# Altium schematic sheet Setback.SchDoc
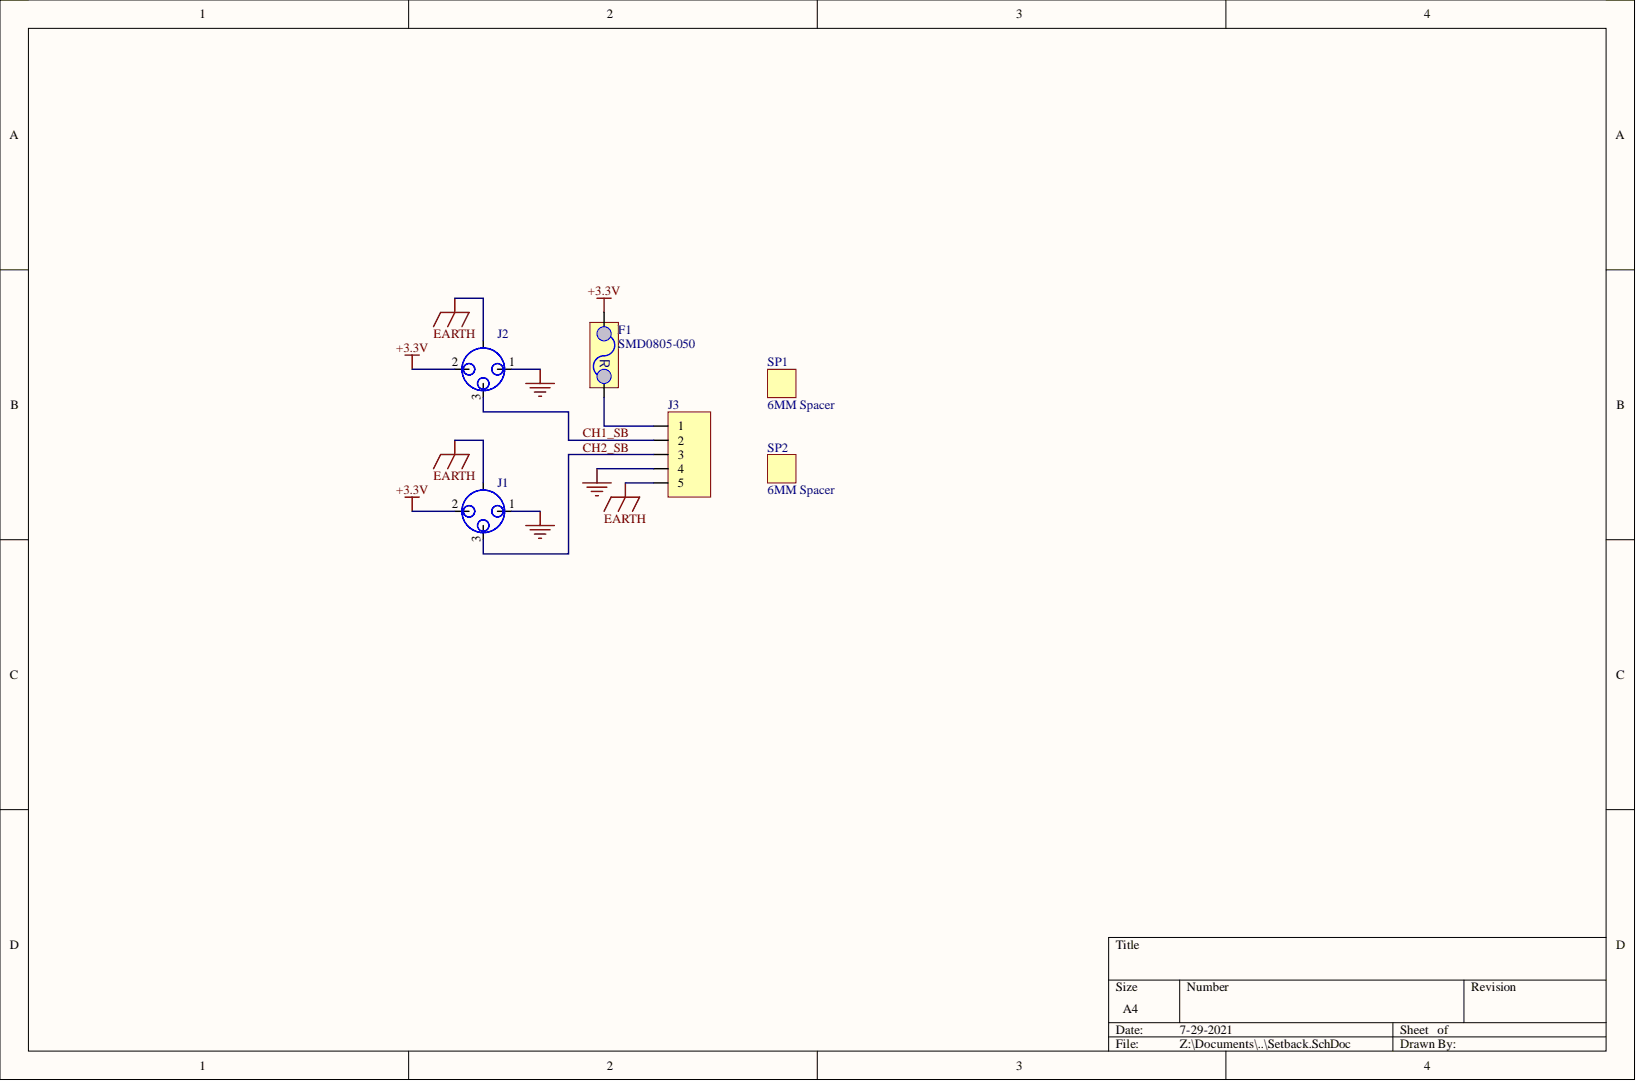
[diagram p0: Setback.SchDoc]

## Parts
F1  SMD0805-050  [SMD0805-050]
J1  DIN-MINI-3-Pin  [MIN-DIN-3-pin-socket]  (value not drawn)
J2  DIN-MINI-3-Pin  [MIN-DIN-3-pin-socket]  (value not drawn)
J3  JST XH Connector, 5-Pin  [jst-b5b-xh-ax]  (value not drawn)
SP1  6MM Spacer  [Spacer-2mm dia x 6mm]
SP2  6MM Spacer  [Spacer-2mm dia x 6mm]

## Nets
+3.3V: F1.1 J1.2 J2.2
EARTH: J1.SH J2.SH J3.5
GND: J1.1 J2.1 J3.4
CH1_SB: J2.3 J3.2
CH2_SB: J1.3 J3.3
NetF1_2: F1.2 J3.1

## Library data of the parts
F1 = SMD0805-050: PTC Resetable Fuse 0805 0.5A hold; Component Kind: Standard; LCSC: C66452; Library Name: MyLibrary.IntLib
J3 = JST-B5B-XH-A: Component Kind: Standard; Description: JST XH Connector, 5-Pin; LatestRevisionDate: 17-Jul-2002; LatestRevisionNote: Re-released for DXP Platform.; Library Name: MyLibrary.IntLib; Signal Integrity: Connector; Publisher: Altium Limited
SP1 SP2 = Spacer-6mm: Component Kind: Standard; Description: 6MM Spacer; Library Name: MyLibrary.IntLib
J1 J2 = DIN-MINI-3-Pin: Component Kind: Standard; Library Name: MyLibrary.IntLib
